# Altium schematic sheet AMS_Master.SchDoc
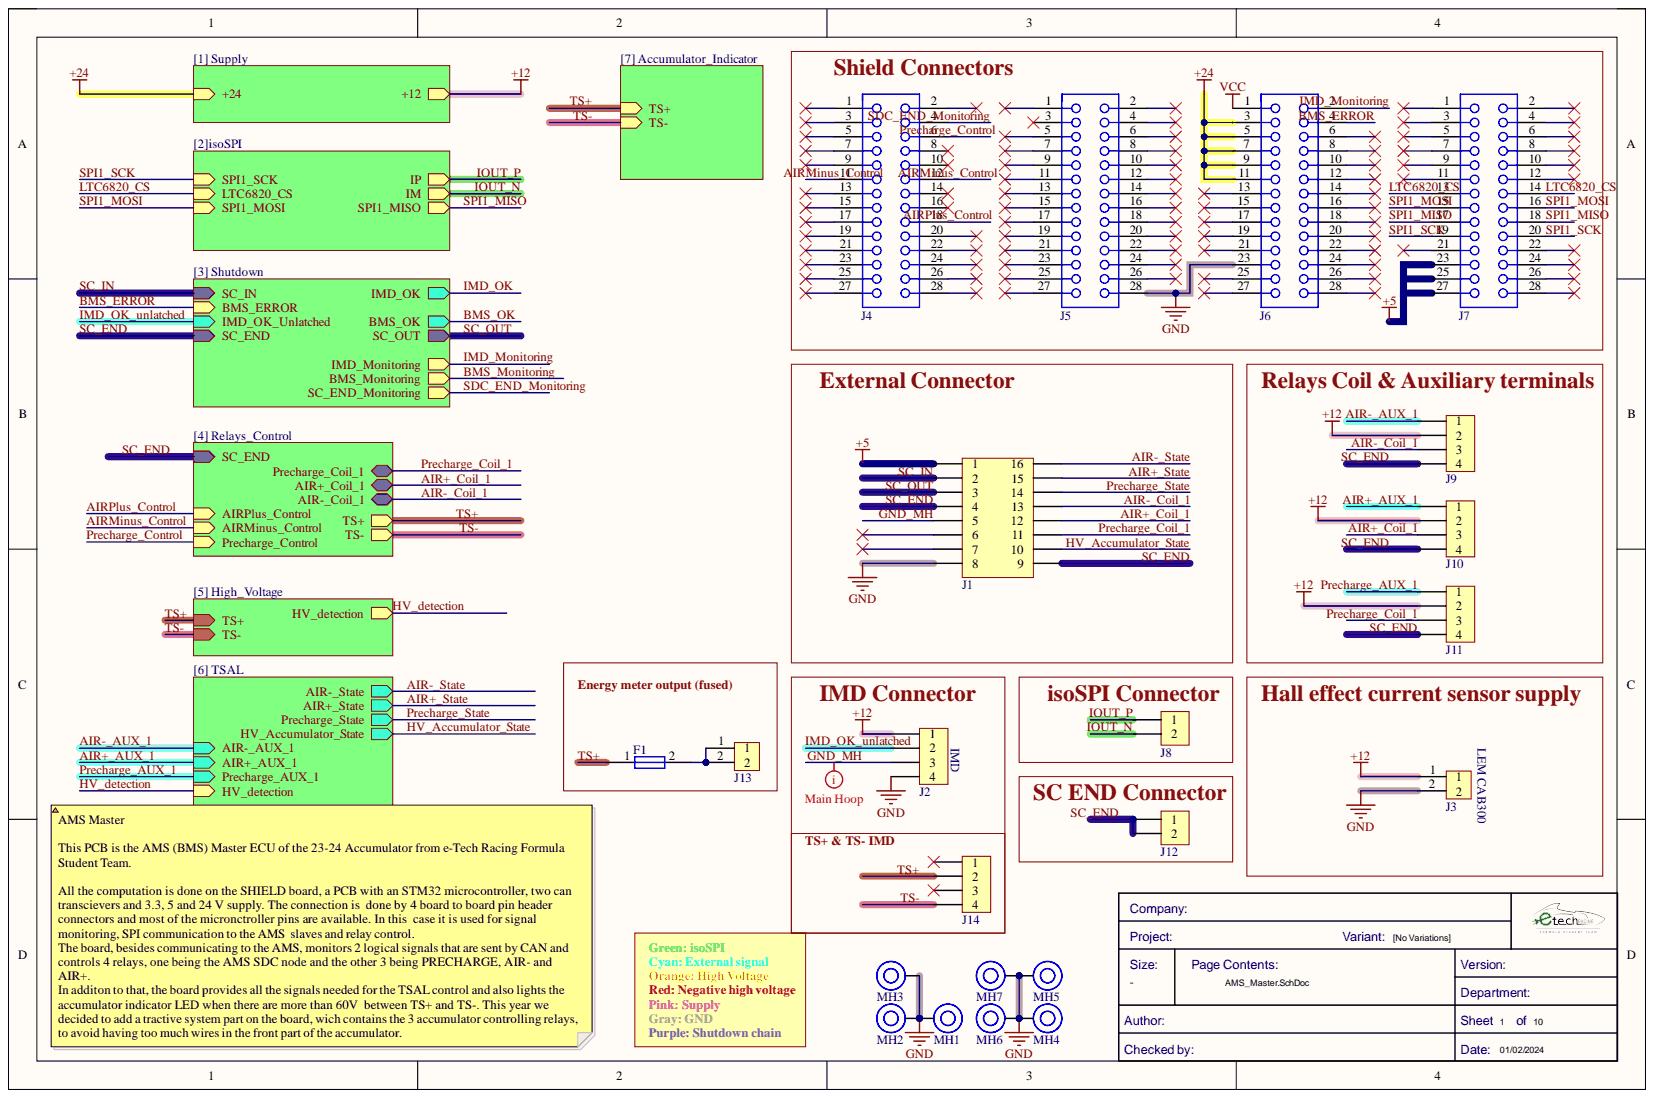
[diagram p0: AMS_Master.SchDoc]

## Parts
F1  FUSE_2.5A_1000V  [FUSE_2.5A_1000V]  (value not drawn)
J1  1053101116  [J_NanoFit_2x8_V]  (value not drawn)
J2  1053091204  [J_NanoFit_1x4_V]  (value not drawn)
J3  436500215  [J_MicroFit_2x1]  (value not drawn)
J4  62332821021  [62332821021]  (value not drawn)
J5  62332821021  [62332821021]  (value not drawn)
J6  62332821021  [62332821021]  (value not drawn)
J7  62332821021  [62332821021]  (value not drawn)
J8  105309-2202  [J_NanoFit_1x2_V]  (value not drawn)
J9  1053091204  [J_NanoFit_1x4_V - duplicate]  (value not drawn)
J10  1053091204  [J_NanoFit_1x4_V - duplicate]  (value not drawn)
J11  1053091204  [J_NanoFit_1x4_V - duplicate]  (value not drawn)
J12  105309-2202  [J_NanoFit_1x2_V]  (value not drawn)
J13  436500215  [J_MicroFit_2x1]  (value not drawn)
J14  436500415  [J_MicroFit_1X4_V]  (value not drawn)
MH1  Mounting_Hole_M4  [Mounting_Hole_M4]  (value not drawn)
MH2  Mounting_Hole_M4  [Mounting_Hole_M4]  (value not drawn)
MH3  Mounting_Hole_M4  [Mounting_Hole_M4]  (value not drawn)
MH4  Mounting_Hole_M4  [Mounting_Hole_M4]  (value not drawn)
MH5  Mounting_Hole_M4  [Mounting_Hole_M4]  (value not drawn)
MH6  Mounting_Hole_M4  [Mounting_Hole_M4]  (value not drawn)
MH7  Mounting_Hole_M4  [Mounting_Hole_M4]  (value not drawn)

## Nets
+5: J1.1 J7.23 J7.25 J7.27
+12: J2.1 J3.1 J9.2 J10.2 J11.2
+24: J6.3 J6.5 J6.7 J6.9 J6.11
GND: J1.8 J2.4 J3.2 J5.28 J6.23 MH1.1 MH2.1 MH3.1 MH4.1 MH5.1 MH6.1 MH7.1
VCC: J6.1
AIR+_AUX_1: J10.1
AIR+_Coil_1: J1.12 J10.3
AIR+_State: J1.15
AIR-_AUX_1: J9.1
AIR-_Coil_1: J1.13 J9.3
AIR-_State: J1.16
AIRMinus_Control: J4.11 J4.12
AIRPlus_Control: J4.18
BMS_ERROR: J6.4
GND_MH: J1.5 J2.3
HV_Accumulator_State: J1.10
IMD_Monitoring: J6.2
IMD_OK_unlatched: J2.2
IOUT_N: J8.2
IOUT_P: J8.1
LTC6820_CS: J7.13 J7.14
Precharge_AUX_1: J11.1
Precharge_Coil_1: J1.11 J11.3
Precharge_Control: J4.6
Precharge_State: J1.14
SC_END: J1.4 J1.9 J9.4 J10.4 J11.4 J12.1 J12.2
SC_IN: J1.2
SC_OUT: J1.3
SDC_END_Monitoring: J4.4
SPI1_MISO: J7.17 J7.18
SPI1_MOSI: J7.15 J7.16
SPI1_SCK: J7.19 J7.20
TS+: F1.1 J14.2
TS-: J14.4
NetF1_2: F1.2 J13.1 J13.2
NetJ1_6: J1.6
NetJ1_7: J1.7
NetJ4_1: J4.1
NetJ4_2: J4.2
NetJ4_3: J4.3
NetJ4_5: J4.5
NetJ4_7: J4.7
NetJ4_8: J4.8
NetJ4_9: J4.9
NetJ4_10: J4.10
NetJ4_13: J4.13
NetJ4_14: J4.14
NetJ4_15: J4.15
NetJ4_16: J4.16
NetJ4_17: J4.17
NetJ4_19: J4.19
NetJ4_20: J4.20
NetJ4_21: J4.21
NetJ4_22: J4.22
NetJ4_23: J4.23
NetJ4_24: J4.24
NetJ4_25: J4.25
NetJ4_26: J4.26
NetJ4_27: J4.27
NetJ4_28: J4.28
NetJ5_1: J5.1
NetJ5_2: J5.2
NetJ5_3: J5.3
NetJ5_4: J5.4
NetJ5_5: J5.5
NetJ5_6: J5.6
NetJ5_7: J5.7
NetJ5_8: J5.8
NetJ5_9: J5.9
NetJ5_10: J5.10
NetJ5_11: J5.11
NetJ5_12: J5.12
NetJ5_13: J5.13
NetJ5_14: J5.14
NetJ5_15: J5.15
NetJ5_16: J5.16
NetJ5_17: J5.17
NetJ5_18: J5.18
NetJ5_19: J5.19
NetJ5_20: J5.20
NetJ5_21: J5.21
NetJ5_22: J5.22
NetJ5_23: J5.23
NetJ5_24: J5.24
NetJ5_25: J5.25
NetJ5_26: J5.26
NetJ5_27: J5.27
NetJ6_6: J6.6
NetJ6_8: J6.8
NetJ6_10: J6.10
NetJ6_12: J6.12
NetJ6_13: J6.13
NetJ6_14: J6.14
NetJ6_15: J6.15
NetJ6_16: J6.16
NetJ6_17: J6.17
NetJ6_18: J6.18
NetJ6_19: J6.19
NetJ6_20: J6.20
NetJ6_21: J6.21
NetJ6_22: J6.22
NetJ6_24: J6.24
NetJ6_25: J6.25
NetJ6_26: J6.26
NetJ6_27: J6.27
NetJ6_28: J6.28
NetJ7_1: J7.1
NetJ7_2: J7.2
NetJ7_3: J7.3
NetJ7_4: J7.4
NetJ7_5: J7.5
NetJ7_6: J7.6
NetJ7_7: J7.7
NetJ7_8: J7.8
NetJ7_9: J7.9
NetJ7_10: J7.10
NetJ7_11: J7.11
NetJ7_12: J7.12
NetJ7_21: J7.21
NetJ7_22: J7.22
NetJ7_24: J7.24
NetJ7_26: J7.26
NetJ7_28: J7.28
NetJ14_1: J14.1
NetJ14_3: J14.3
BMS_Monitoring:
BMS_OK:
HV_detection:
IMD_OK:

## Library data of the parts
J1 = J_NanoFit_2x8_V: Nano-Fit Vertical Header Through Hole 2.50mm Pitch Dual Row 16 Circuits with Kinked Pins Tin (Sn) Plating Black; Manufacturer 1: Molex; Manufacturer Part Number 1: 105310-1116; .Creator: AC; Connector Type: Header,Male Pin; Number of Positions: 16; Category: Headers and Wire Housings; Component Kind: Standard; ComponentLink1Description: https://www.molex.com/pdm_docs/sd/1053101210_sd.pdf?src-supplier=Digi-Key; ComponentLink1URL: https://www.molex.com/pdm_docs/sd/1053101210_sd.pdf?src-supplier=Digi-Key; ComponentLink2Description: Molex; ComponentLink2URL: https://www.molex.com/molex/products/part-detail/pcb_headers/1053101116; Contact Material: Brass; Contact Plating: Tin; Contact Shape: Square; Fastening Type: Latch; Insulation Color: Black; Insulation Material: Polymer; Library Name: Electromechanical.SchLib; Max Operating Temperature: 105°C; Min Operating Temperature: -40°C; Mount: Through Hole; Number of Rows: 2; Packaging: Tray; Pricing 1: 1=3,21000, 10=2,82400, 84=2,24619, 1008=1,86110, 4956=1,66858 (USD); RoHS: No; Stock 1: 84; Supplier 1: Digi-Key; Supplier Part Number 1: WM16885-ND; Termination: Kinked Pin
J2 J9-J11 = J_NanoFit_1x4_V: Nano-Fit Vertical Header Through Hole 2.50mm Pitch Single Row 4 Circuits with Kinked Pins 0.38; Manufacturer 1: Molex; Manufacturer Part Number 1: 105309-1204; .Creator: DO; Category: Headers and Wire Housings; Component Kind: Standard; ComponentLink1Description: http://farnell.com//datasheets/2221349.pdf?src-supplier=Farnell; ComponentLink1URL: http://farnell.com//datasheets/2221349.pdf?src-supplier=Farnell; ComponentLink2Description: http://www.farnell.com/datasheets/2221349.pdf?src-supplier=Newark; ComponentLink2URL: http://www.farnell.com/datasheets/2221349.pdf?src-supplier=Newark; ComponentLink3Description: http://www.literature.molex.com/SQLImages/kelmscott/Molex/PDF_Images/987651-1223.PDF?src-supplier=Digi-Key; ComponentLink3URL: http://www.literature.molex.com/SQLImages/kelmscott/Molex/PDF_Images/987651-1223.PDF?src-supplier=Digi-Key; ComponentLink4Description: http://www.farnell.com/datasheets/2059602.pdf?src-supplier=Element14; ComponentLink4URL: http://www.farnell.com/datasheets/2059602.pdf?src-supplier=Element14; Library Name: Electromechanical.SchLib; Pricing 1: 1=1,37050, 10=1,11700, 100=0,95270, 500=0,86980, 1000=0,74060, 2500=0,71930, 5000=0,68450, 10000=0,66860 (USD); RoHS: No; Stock 1: 709; Supplier 1: Arrow Electronics; Supplier Part Number 1: 105309-1204
J3 J13 = J_MicroFit_2x1: Header 1 Row Vertical Polarizing Peg 2 Pin SMT/Glow Wire Compatible Tin Plate; Manufacturer 1: Molex; Manufacturer Part Number 1: 43650-0215; Category: Headers and Wire Housings; Component Kind: Standard; ComponentLink1Description: https://datasheet.ciiva.com/19462/884109-19462034.pdf?src-supplier=Jameco; ComponentLink1URL: https://datasheet.ciiva.com/19462/884109-19462034.pdf?src-supplier=Jameco; ComponentLink2Description: https://datasheet.ciiva.com/5157/0436500215-pcb-headers-148687-5157608.pdf?src-supplier=Mouser; ComponentLink2URL: https://datasheet.ciiva.com/5157/0436500215-pcb-headers-148687-5157608.pdf?src-supplier=Mouser; ComponentLink3Description: https://datasheet.ciiva.com/16085/0436500215-pcb-headers-16085668.pdf?src-supplier=Onlinecomponents.com; ComponentLink3URL: https://datasheet.ciiva.com/16085/0436500215-pcb-headers-16085668.pdf?src-supplier=Onlinecomponents.com; ComponentLink4Description: https://datasheet.ciiva.com/11748/0900766b80307ce2-11748388.pdf?src-supplier=RSComponents; ComponentLink4URL: https://datasheet.ciiva.com/11748/0900766b80307ce2-11748388.pdf?src-supplier=RSComponents; ComponentLink5Description: https://datasheet.ciiva.com/9432/0436500215-pcb-headers-9432850.pdf?src-supplier=Avnet; ComponentLink5URL: https://datasheet.ciiva.com/9432/0436500215-pcb-headers-9432850.pdf?src-supplier=Avnet; ComponentLink6Description: https://datasheet.ciiva.com/7920/1889390-7920892.pdf?src-supplier=Element14; ComponentLink6URL: https://datasheet.ciiva.com/7920/1889390-7920892.pdf?src-supplier=Element14; Library Name: Electromechanical.SchLib; Pricing 1: 1=0,87800, 10=0,74400, 80=0,63070, 3264=0,47000, 6528=0,45100, 13056=0,41760 (USD); RoHS: Yes; Stock 1: 11086; Supplier 1: Arrow Electronics; Supplier Part Number 1: 43650-0215
J4-J7 = 62332821021: WR-PHD Socket Header, SMT, Vertical, pitch 1.27mm, 2 Row, 28P; Manufacturer: Wurth Elektronik; Contact Resistance: 20mΩ; Rated Current: 1A; Withstanding Voltage: 500V; Working Voltage: 250V; Category: Board-to-Board Connectors; Component Kind: Standard; ComponentLink1Description: Website Link; ComponentLink1URL: https://www.we-online.com/redexpert/article/62332821021?ad; ComponentLink2Description: Datasheet Link; ComponentLink2URL: https://www.we-online.com/redexpert/spec/62332821021?ad; Gender: Socket Header; Library Name: Electromechanical.SchLib; Manufacturer Part Number: 62332821021; Match Code: WR-PHD; Mount: Surface Mount; Number of Pins: 28; Number of Rows: 2; Operating Temperature Max: 105°C; Operating Temperature Min: -40°C; Orientation: Vertical; Packaging: Tape and Reel; Pitch: 1.27mm
J8 J12 = J_Nanofit_1x2_V: Nano-Fit Vertical Header, Through Hole, 2.50mm Pitch, Single Row, 2 Circuits, with Kinked Pins, Tin (Sn) Plating,...; Manufacturer: Molex; Category: Uncategorized; Component Kind: Standard; ComponentLink1Description: https://www.molex.com/pdm_docs/sd/1053092202_sd.pdf?src-supplier=Digi-Key; ComponentLink1URL: https://www.molex.com/pdm_docs/sd/1053092202_sd.pdf?src-supplier=Digi-Key; Library Name: Electromechanical.SchLib; Manufacturer Part Number: 105309-2202; Pricing 1: 1=1,1, 10=0,888, 50=0,888, 100=0,735, 1000=0,59, 10000=0,515 (USD); RoHS: Yes; Stock 1: 6319; Supplier 1: Mouser; Supplier Part Number 1: 538-105309-2202
J14 = J_Microfit_1x4_V: Component Kind: Standard; Library Name: Electromechanical.SchLib
F1 = FUSE_2.5A_1000V: Component Kind: Standard; Library Name: Filter components.SchLib
MH1-MH7 = Mounting_Hole_M4: Component Kind: Standard; Library Name: Electromechanical.SchLib
